# Altium schematic sheet 07_NINA-WIFI.SchDoc
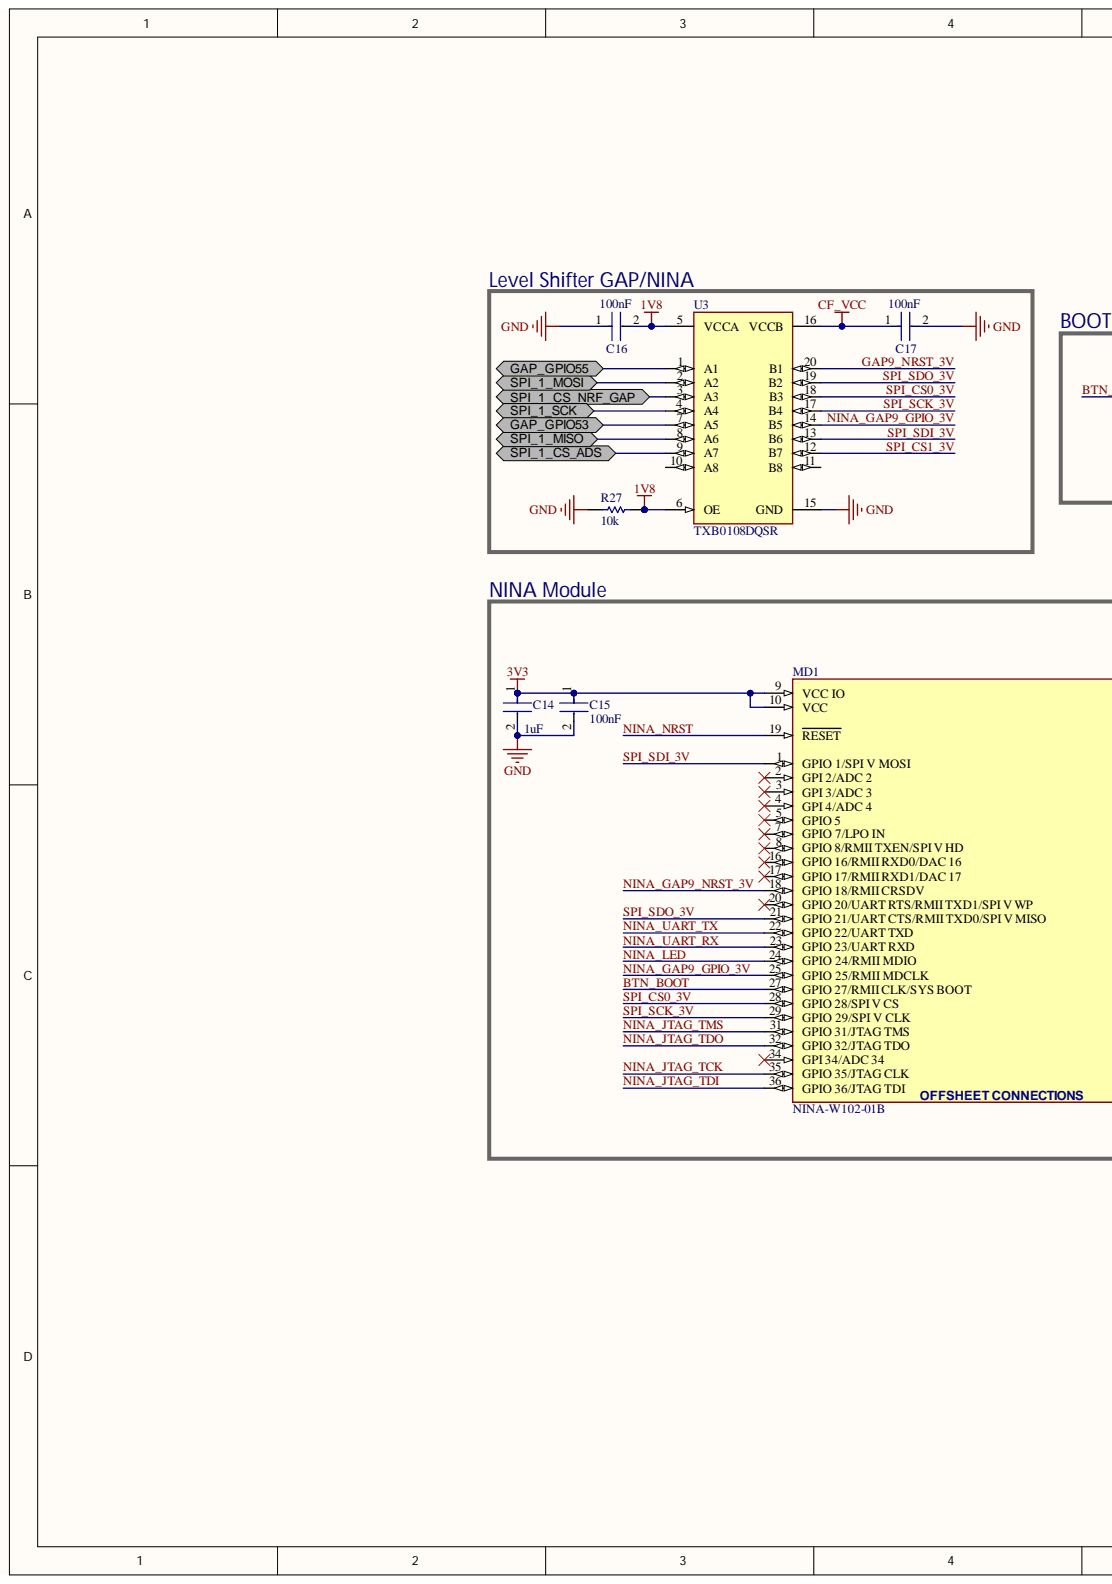
[diagram p0: 07_NINA-WIFI.SchDoc, left]
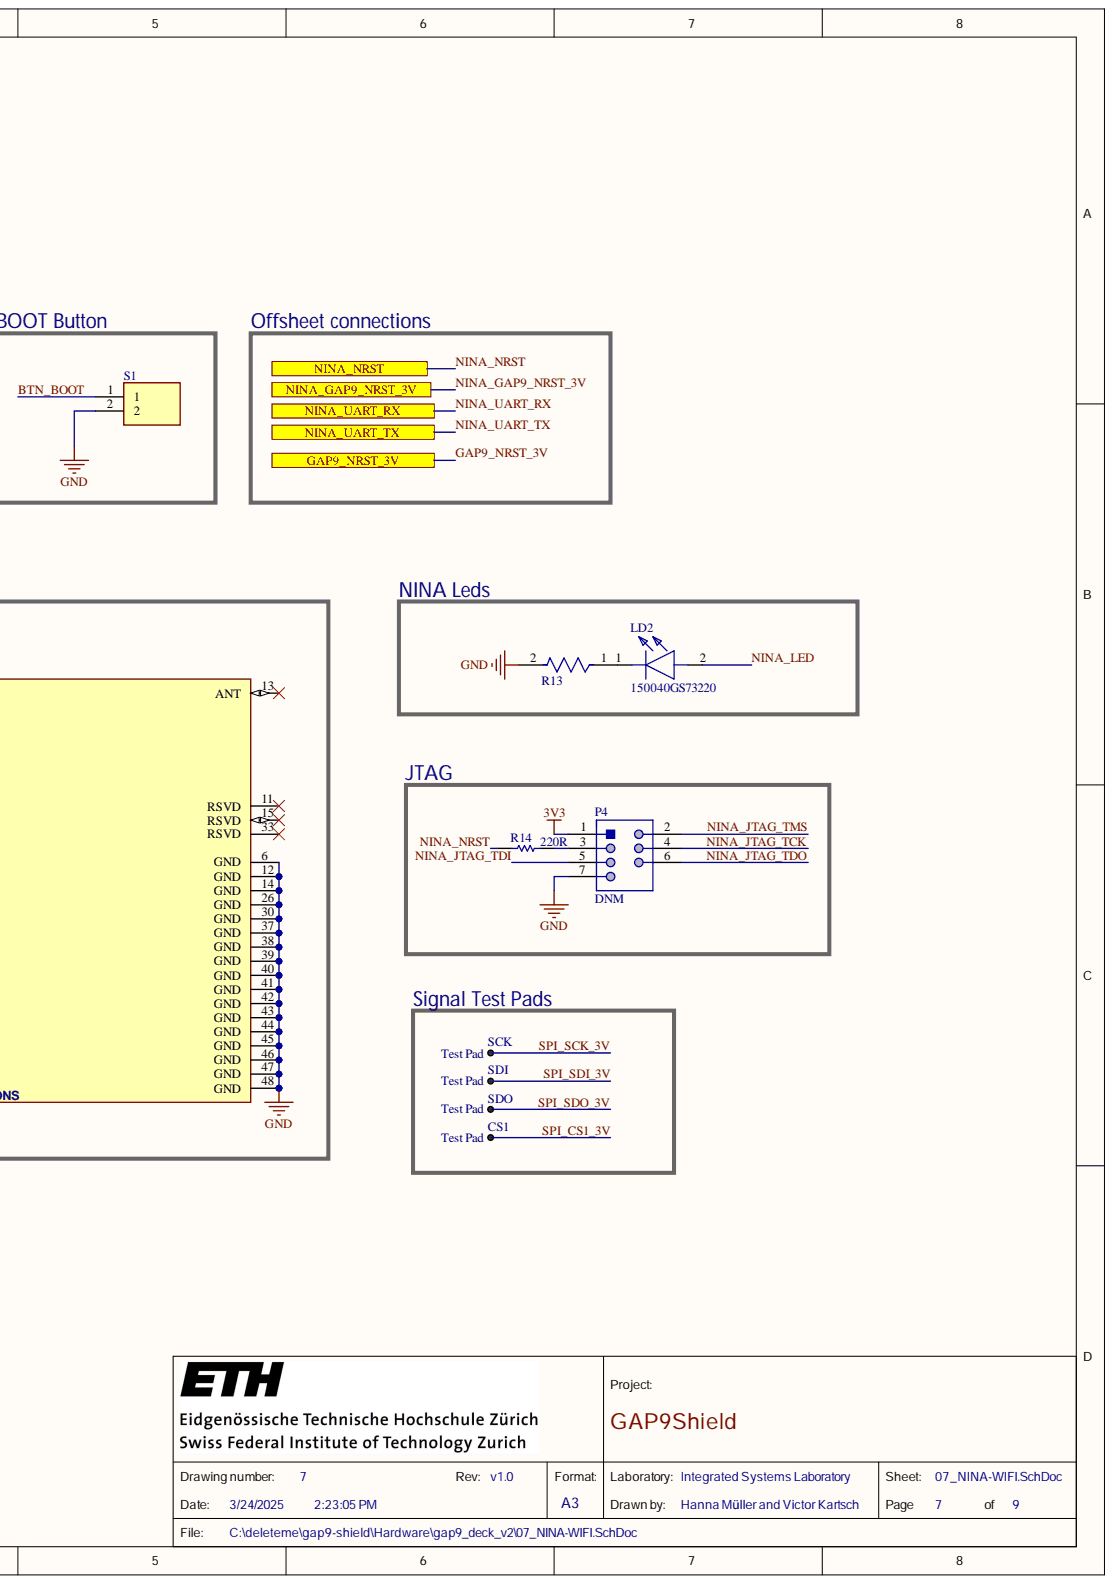
[diagram p1: 07_NINA-WIFI.SchDoc, right]

## Parts
C14  value 1uF  Capacitance: 1uF  [FP-GRM155-0_05-IPC_B]  (hidden comment: GRM155R61E105MA12D)
C15  value 100nF  Capacitance: 100nF  [FP-C1005-050-0_05-MFG]  (hidden comment: C1005X5R1E104K050BC)
C16  value 100nF  Capacitance: 100nF  [FP-C1005-050-0_05-MFG]  (hidden comment: C1005X5R1E104K050BC)
C17  value 100nF  Capacitance: 100nF  [FP-C1005-050-0_05-MFG]  (hidden comment: C1005X5R1E104K050BC)
CS1  Test Pad  [Pad-1.5mm]  (no BOM)
LD2  150040GS73220  [150040GS73220]
MD1  NINA-W102-01B  [FP-NINA-W102-00B-00-MFG]
P4  DNM  [JTAG_MINI]
R13  RC0201JR-070RL  [FP-RC_L0201-MFG]  (value not drawn)
R14  value 220R  [RESC0603X28X15ML05T05]  (hidden comment: CRCW0201220RFKED)
R27  value 10k  [RESC0603X28X15LL05T05]  (hidden comment: CRCW020110K0FNED)
S1  TS19-32-06-BK-70-1-SMT-TR-67  [TS193206BK701SMTTR67]  (value not drawn)
SCK  Test Pad  [Pad-1.5mm]  (no BOM)
SDI  Test Pad  [Pad-1.5mm]  (no BOM)
SDO  Test Pad  [Pad-1.5mm]  (no BOM)
U3  TXB0108DQSR  [FP-DQS0020A-MFG]

## Ports
GAP9_NRST_3V, GAP_GPIO53, GAP_GPIO55, NINA_GAP9_NRST_3V, NINA_NRST, NINA_UART_RX, NINA_UART_TX, SPI_1_CS_ADS, SPI_1_CS_NRF_GAP, SPI_1_MISO, SPI_1_MOSI, SPI_1_SCK

## Nets
1V8: C16.2 R27.1 U3.5(VCCA) U3.6(OE)
3V3: C14.1 C15.1 MD1.9(VCC_IO) MD1.10(VCC) P4.1
CF_VCC: C17.1 U3.16(VCCB)
GND: C14.2 C15.2 C16.1 C17.2 MD1.6(GND) MD1.12(GND) MD1.14(GND) MD1.26(GND) MD1.30(GND) MD1.37(GND) MD1.38(GND) MD1.39(GND) MD1.40(GND) MD1.41(GND) MD1.42(GND) MD1.43(GND) MD1.44(GND) MD1.45(GND) MD1.46(GND) MD1.47(GND) MD1.48(GND) P4.7 R13.2 R27.2 S1.2 U3.15(GND)
BTN_BOOT: MD1.27(GPIO_27/RMII_CLK/SYS_BOOT) S1.1
GAP9_NRST_3V: U3.20(B1) ; port GAP9_NRST_3V
GAP_GPIO53: U3.7(A5) ; port GAP_GPIO53
GAP_GPIO55: U3.1(A1) ; port GAP_GPIO55
NINA_GAP9_GPIO_3V: MD1.25(GPIO_25/RMII_MDCLK) U3.14(B5)
NINA_GAP9_NRST_3V: MD1.18(GPIO_18/RMII_CRSDV) ; port NINA_GAP9_NRST_3V
NINA_JTAG_TCK: MD1.35(GPIO_35/JTAG_CLK) P4.4
NINA_JTAG_TDI: MD1.36(GPIO_36/JTAG_TDI) P4.5
NINA_JTAG_TDO: MD1.32(GPIO_32/JTAG_TDO) P4.6
NINA_JTAG_TMS: MD1.31(GPIO_31/JTAG_TMS) P4.2
NINA_LED: LD2.2 MD1.24(GPIO_24/RMII_MDIO)
NINA_NRST: MD1.19(RESET) R14.2 ; port NINA_NRST
NINA_UART_RX: MD1.23(GPIO_23/UART_RXD) ; port NINA_UART_RX
NINA_UART_TX: MD1.22(GPIO_22/UART_TXD) ; port NINA_UART_TX
SPI_1_CS_ADS: U3.9(A7) ; port SPI_1_CS_ADS
SPI_1_CS_NRF_GAP: U3.3(A3) ; port SPI_1_CS_NRF_GAP
SPI_1_MISO: U3.8(A6) ; port SPI_1_MISO
SPI_1_MOSI: U3.2(A2) ; port SPI_1_MOSI
SPI_1_SCK: U3.4(A4) ; port SPI_1_SCK
SPI_CS0_3V: MD1.28(GPIO_28/SPI_V_CS) U3.18(B3)
SPI_CS1_3V: CS1.1 U3.12(B7)
SPI_SCK_3V: MD1.29(GPIO_29/SPI_V_CLK) SCK.1 U3.17(B4)
SPI_SDI_3V: MD1.1(GPIO_1/SPI_V_MOSI) SDI.1 U3.13(B6)
SPI_SDO_3V: MD1.21(GPIO_21/UART_CTS/RMII_TXD0/SPI_V_MISO) SDO.1 U3.19(B2)
NetLD2_1: LD2.1 R13.1
NetP4_3: P4.3 R14.1

Not connected: MD1 2(GPI_2/ADC_2) 3(GPI_3/ADC_3) 4(GPI_4/ADC_4) 5(GPIO_5) 7(GPIO_7/LPO_IN) 8(GPIO_8/RMII_TXEN/SPI_V_HD) 11(RSVD) 13(ANT) 15(RSVD) 16(GPIO_16/RMII_RXD0/DAC_16) 17(GPIO_17/RMII_RXD1/DAC_17) 20(GPIO_20/UART_RTS/RMII_TXD1/SPI_V_WP) 33(RSVD) 34(GPI_34/ADC_34); U3 10(A8) 11(B8)

## Library data of the parts
C14 = CMP-06035-012395-1: Chip Multilayer Ceramic Capacitors for General Purpose, 0402, 1.0uF, X5R, 15%, 20%, 25V; Case Code (Imperial): 0402; Case Code (Metric): 1005; Case/Package: 0402; Component Kind: Standard; Dielectric: X5R; Height: 0.5mm; Lead Free: Lead Free; Length: 0.039inch; Library Name: Altium Content Vault; Max Operating Temperature: 85°C; Min Operating Temperature: -55°C; Mount: Surface Mount; Package Quantity: 10000; Packaging: Tape and Reel; Termination: SMD/SMT; Thickness: 0.022inch; Tolerance: 20%; Voltage Rating: 25V; Voltage Rating (DC): 25V; Weight: 0.000212oz; Width: 0.5mm
C15-C17 = CMP-2000-06000-2: Multilayer Ceramic Capacitors 100nF ±10% 25V X5R SMD 0402; Case Code (Imperial): 0402; Case Code (Metric): 1005; Case/Package: 0402; Component Kind: Standard; Construction: Flat; Contact Plating: Tin; Depth: 0.5mm; Dielectric: X5R; Dielectric Material: Ceramic; Height: 0.5mm; Lead Free: Lead Free; Length: 1mm; Library Name: Altium Content Vault; Max Operating Temperature: 85°C; Min Operating Temperature: -55°C; Mount: Surface Mount; Package Quantity: 1; Packaging: Tape and Reel; REACH SVHC: No SVHC; Resistor Type: Ceramic; RoHS Compliant: Yes; Series: C; Termination: Wraparound; Thickness: 0.022inch; Tolerance: 10%; Voltage: 25V; Voltage Rating: 25V; Voltage Rating (DC): 25V; Weight: 2.3E-05oz; Width: 0.02inch
CS1 SCK SDI SDO = CMP-01283-1: 1mm SMT Test Pad; Mounting Technology: SMT; Library Name: gap9_deck.SCHLIB
LD2 = 150040GS73220: LED; Manufacturer_Name: Wurth Elektronik; Component Kind: Standard; Datasheet Link: https://www.mouser.com/datasheet/2/445/150040GS73220-1714597.pdf; Height: 0.35mm; Manufacturer_Part_Number: 150040GS73220; Mouser Part Number: 710-150040GS73220; Mouser Price/Stock: https://www.mouser.co.uk/ProductDetail/Wurth-Elektronik/150040GS73220?qs=u16ybLDytRZ9vktXLUK1Kg%3D%3D
MD1 = CMP-207130-000007-1: STAND-ALONE MULTIRADIO MODULES; Manufacturer: U-Blox; Manufacturer Part Number: NINA-W102-00B-00; Bandwidth: 20MHz; Data Rate: 100Mbits/s; Interface: I2C,SPI,UART,CAN,Ethernet,I2S,Other; Max Frequency: 2.5GHz; Max Supply Voltage: 3.6V; Min Supply Voltage: 3V; Nominal Supply Current: 30-190mA; Number Of Adc Channels: 17; Number Of Gpio: 20; Receiving Current: 100-140mA; Sensitivity: -96dBm; Transmitting Current: 225-320mA; Automotive: No; Category: UNK; Component Kind: Standard; ComponentLink2Description: Datasheet; ComponentLink2URL: https://www.u-blox.com/sites/default/files/NINA-W10_DataSheet_%28UBX-17065507%29.pdf; Device Class L1: Integrated Circuits (ICs); Device Class L2: RF Semiconductors and Devices; Device Class L3: Transceivers; Digikey Description: STAND-ALONE MULTIRADIO MODULES; Height: 4.2mm; Lead Free: Yes; Library Name: Altium Content Vault; Octopart: https://octopart.com/nina-w102-00b-00-u-blox-103157672; Package: 0; RoHS: TRUE; Temperature: -40°C to +85°C; Temperature Range High: +85°C; Temperature Range Low: -40°C
P4 = JTAG_MINI: 2x10 Pin 50mil Header; PN: 20021111-00010T4LF; Component Kind: Standard; Supplier 1: Digi-Key; Supplier Part Number 1: 732-5374-ND; Library Name: gap9_deck.SCHLIB
R13 = CMP-03412-023265-1: RES SMD 0 OHM JUMPER 1/20W 0201; Manufacturer: Yageo; Power Rating: 50mW; Resistance: 0Ω; Temperature Coefficient: 200ppm/°C; Tolerance: 5%; Value: 0Ω; Voltage Rating: 25V; Automotive: No; Category: Res; Component Kind: Standard; ComponentLink2Description: Datasheet; ComponentLink2URL: http://www.yageo.com/documents/recent/PYu-RC_Group_51_RoHS_L_10.pdf; Composition: Thick Film; Device Class L1: Passive Components; Device Class L2: Resistors; Device Class L3: Chip SMD Resistors; Digikey Description: RES SMD 0 OHM JUMPER 1/20W 0201; Footprint Url: http://www.yageo.com/exep/pages/download/literatures/PYu-R_Mount_10.pdf; Height: 0.26mm; Ipc Land Pattern Name: RESC6030X23; Lead Free: Yes; Library Name: Altium Content Vault; Manufacturer Part Number: RC0201JR-070RL; Mouser Description: Thick Film Resistors ZERO OHM JUMPER; Octopart: https://octopart.com/rc0201jr-070rl-yageo-43171986; Package: RC_L0201; RoHS: TRUE; Series: RC_L; Temperature: -55°C to +125°C; Temperature Range High: +125°C; Temperature Range Low: -55°C
R14 = CMP-2004-00982-1: Manufacturer: Vishay Dale; Component Kind: Standard; Library Name: Altium Content Vault; Part Number: CRCW0201220RFKED
R27 = CMP-2004-00016-1: Manufacturer: Vishay Dale; Component Kind: Standard; Library Name: Altium Content Vault; Part Number: CRCW020110K0FNED
S1 = TS19-32-06-BK-70-1-SMT-TR-67: Switch; Manufacturer_Name: CUI Devices; Component Kind: Standard; Datasheet Link: https://www.cuidevices.com/product/resource/ts19.pdf; Height: 0.65mm; Library Name: SamacSys.SchLib; Manufacturer_Part_Number: TS19-32-06-BK-70-1-SMT-TR-67; Mouser Part Number: 179-TS193206BK701SM; Mouser Price/Stock: https://www.mouser.co.uk/ProductDetail/CUI-Devices/TS19-32-06-BK-70-1-SMT-TR-67?qs=tlsG%2FOw5FFj0aJkgA5InKg%3D%3D
U3 = CMP-04899-000009-1: IC TRNSLTR BIDIRECTIONAL 20USON; Manufacturer: Texas Instruments; Data Rate: 100Mbits/s; Logic Function Desc: Non-Inverting; Max Junction Temp: +150°C; Max Supply Voltage: 5.5V; Min Supply Voltage: 1.2V; Nominal Supply Current: 0.05-3.5uA; Number Of Circuits: 8; Number Of Inputs: 1; Propagation Delay: 4ns; Standoff Height: 0mm; V A Max: 3.6V; V B Min: 1.65V; Ambient Temperature Range High: +85°C; Ambient Temperature Range Low: -40°C; Automotive: No; Category: IC; Component Kind: Standard; ComponentLink2Description: Datasheet; ComponentLink2URL: https://www.ti.com/lit/ds/symlink/txb0108.pdf; Device Class L1: Integrated Circuits (ICs); Device Class L2: Logic ICs; Device Class L3: Voltage Level Shifters; Digikey Description: IC TRNSLTR BIDIRECTIONAL 20USON; Height: 0.55mm; Lead Free: Yes; Library Name: Altium Content Vault; Manufacturer Part Number: TXB0108DQSR; Mouser Description: Translation - Voltage Levels 8B Bidir Vltg-Level Translator; Number Of Outputs: 1; Octopart: https://octopart.com/txb0108dqsr-texas+instruments-18562890; Package: DQS0020A; RoHS: TRUE; Temperature: -40°C to +85°C; Temperature Range High: +85°C; Temperature Range Low: -40°C; V A Min: 1.2V; V B Max: 5.5V
